# Altium schematic sheet LTE_UART_USB_adapter.SchDoc
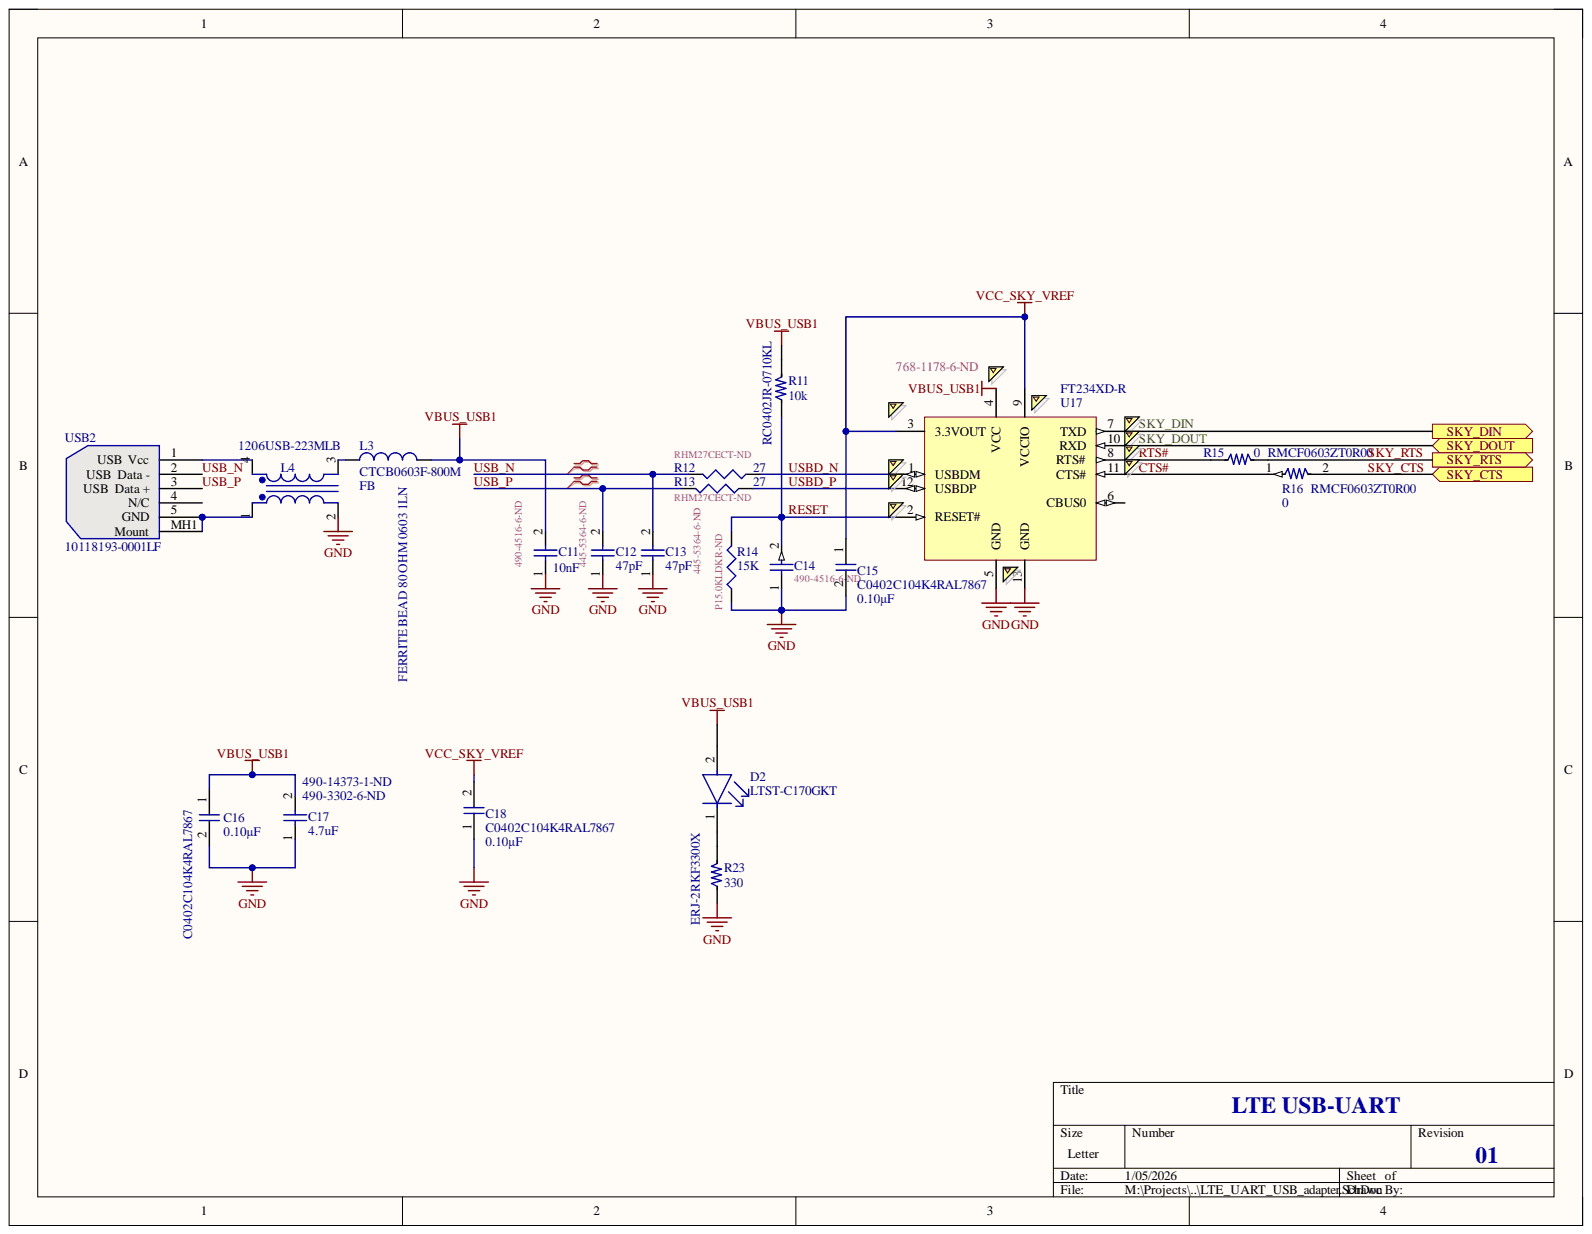
[diagram p0: LTE_UART_USB_adapter.SchDoc]

## Parts
C11  Capacitance: 10nF  Supplier Part Number 1: 490-4516-6-ND  [0402 (1005 Metric)]  (hidden comment: GRM155R71H103KA88D)
C12  Capacitance: 47pF  Supplier Part Number 1: 445-5364-6-ND  [0402 (1005 Metric)]  (hidden comment: C1005C0G1H470G050BA)
C13  Capacitance: 47pF  [0402 (1005 Metric)]  (hidden comment: C1005C0G1H470G050BA)
C14  Supplier Part Number 1: 490-4516-6-ND  [0402 (1005 Metric)]  (hidden comment: GRM155R71H103KA88D)
C15  C0402C104K4RAL7867  Capacitance: 0.10µF  [SMD CAP 1005]
C16  C0402C104K4RAL7867  Capacitance: 0.10µF  [SMD CAP 1005]
C17  Capacitance: 4.7uF  Digi-Key Part Number: 490-14373-1-ND  Supplier Part Number 1: 490-3302-6-ND  [0603 (1608 Metric)]  (hidden comment: GRM188R61A475KAAJD)
C18  C0402C104K4RAL7867  Capacitance: 0.10µF  [SMD CAP 1005]
D2  LTST-C170GKT  [FP-LTST-C170GKT-MFG]
L3  CTCB0603F-800M  value FB  [INDC1608M]
L4  1206USB-223MLB  [1206USB-223MLB]
R11  RC0402JR-0710KL  Resistance_Ohms: 10k  [SMD RES 1005]
R12  value 27  Digi-Key Part Number: RHM27CECT-ND  [SMD RES 1005]  (hidden comment: MCR01MRTJ270)
R13  value 27  Digi-Key Part Number: RHM27CECT-ND  [SMD RES 1005]  (hidden comment: MCR01MRTJ270)
R14  value 15K  Supplier Part Number 1: P15.0KLDKR-ND  [SMD RES 1005]  (hidden comment: ERJ-2RKF1502X)
R15  RMCF0603ZT0R00  [RESC1608M]
R16  RMCF0603ZT0R00  [RESC1608M]
R23  ERJ-2RKF3300X  [SMD RES 1005]
U17  FT234XD-R  Supplier Part Number 1: 768-1178-6-ND  [DFN-12_V]
USB2  10118193-0001LF  [10118193-0001LF Rev01]

## Ports
SKY_CTS, SKY_DIN, SKY_DOUT, SKY_RTS

## Nets
GND: C11.1 C12.1 C13.1 C14.1 C15.2 C16.2 C17.1 C18.1 L4.2 R14.1 R23.1 U17.5(GND) U17.13(GND)
VBUS_USB1: C11.2 C16.1 C17.2 D2.2 L3.1 R11.2 U17.4(VCC)
VCC_SKY_VREF: C15.1 C18.2 U17.3(3.3VOUT) U17.9(VCCIO)
CTS#: R16.1 U17.11(CTS#)
RESET: C14.2 R11.1 R14.2 U17.2(RESET#)
RTS#: R15.1 U17.8(RTS#)
SKY_CTS: R16.2 ; port SKY_CTS
SKY_DIN: U17.7(TXD) ; port SKY_DIN
SKY_DOUT: U17.10(RXD) ; port SKY_DOUT
SKY_RTS: R15.2 ; port SKY_RTS
USB_N: C13.2 R12.2 USB2.2(Data_-)
USB_P: C12.2 R13.2 USB2.3(Data_+)
USBD_N: R12.1 U17.1(USBDM)
USBD_P: R13.1 U17.12(USBDP)
NetD2_1: D2.1 R23.2
NetL3_2: L3.2 L4.3
NetL4_1: L4.1 USB2.5(GND) USB2.MH1(Mount)
NetL4_4: L4.4 USB2.1(Vcc)
NetU17_6: U17.6(CBUS0)
NetUSB2_4: USB2.4(N/C)

## Library data of the parts
C11 = Cap Semi: 10000 pF ±10% 50V Ceramic Capacitor X7R 0402 (1005 Metric); Manufacturer: Murata Electronics North America; Component Kind: Standard; Cost: 0.02558; Digi-Key Part Number: 490-4516-1-ND; Manufacturer Product Number: GRM155R71H103KA88D; PackageDescription: Chip Capacitor; PackageReference: 0402; Signal Integrity: Cap Semi; Simulation: CAP; Supplier 1: Digi-Key; Library Name: TempProbe.SchLib
C12 C13 = Cap Semi: 47 pF ±2% 50V Ceramic Capacitor C0G, NP0 0402 (1005 Metric); Manufacturer: TDK Corporation; Component Kind: Standard; Cost: 0.02558; Digi-Key Part Number: 445-5364-1-ND; Manufacturer Product Number: C1005C0G1H470G050BA; PackageDescription: Chip Capacitor; PackageReference: 0402; Signal Integrity: Cap Semi; Simulation: CAP; Supplier 1: Digi-Key; Library Name: TempProbe.SchLib
  C13: Supplier Part Number 1: 445-5364-6-ND
C14 = Cap Semi: 10000 pF ±10% 50V Ceramic Capacitor X7R 0402 (1005 Metric); Manufacturer: Murata Electronics North America; Capacitance: 10nF; Component Kind: Standard; Cost: 0.02558; Digi-Key Part Number: 490-4516-1-ND; Manufacturer Product Number: GRM155R71H103KA88D; PackageDescription: Chip Capacitor; PackageReference: 0402; Signal Integrity: Cap Semi; Simulation: CAP; Supplier 1: Digi-Key; Library Name: TempProbe.SchLib
C15 C16 C18 = 1000742: 0.1 µF ±10% 16V Ceramic Capacitor X7R 0402 (1005 Metric); Manufacturer: KEMET; Manufacturer_Part_Number1: C0402C104K4RALTU; Item_Phase: Production; Mounting_Type: Surface Mount; Operating_Temperature: -55°C ~ 125°C; Thickness_Max: 0.022" (0.55mm); Value: 0.1uF; Applications: General Purpose; Bom_View: https://app.bom.com/a/YGZ57I1I1KJQDBOE?v=bom.indented; Capacitor_Type: Ceramic; Component Kind: Standard; Costing_View: https://app.bom.com/a/YGZ57I1I1KJQDBOE?v=costing.summary; Database Table Name: Capacitor; Datasheet_Link: http://www.samsungsem.com/kr/support/product-search/mlcc/CL05B104KO5NNNC.jsp; Digi-Key Part Number: 399-C0402C104K4RAL7867CT-ND; Distributor1: Digi-Key; Distributor2: Digi-Key; Distributor_Part_Number1: 399-6342-1-ND; Distributor_Part_Number2: 1276-1001-1-ND; Files_View: https://app.bom.com/a/YGZ57I1I1KJQDBOE?v=files.associations; Library Name: AltiumProductionDatabase.DbLib; Manufacturer1: Kemet; Manufacturer2: Samsung Electro-Mechanics America, Inc; Manufacturer Product Number: C0402C104K4RAL7867; Manufacturer_Part_Number2: CL05B104KO5NNNC; Package_Case: 0402 (1005 Metric); Part_Number: 1000742; Part_Type: Capacitor; Revision: A; Size_Dimension: 0.039" L x 0.020" W (1.00mm x 0.50mm); Sourcing_View: https://app.bom.com/a/YGZ57I1I1KJQDBOE?v=sourcing.relationships; Specs_View: https://app.bom.com/a/YGZ57I1I1KJQDBOE?v=spec.summary; Temperature_Coefficient: X7R; Tolerance: ±10%; Voltage_Rating: 16V
C17 = Cap Semi: 4.7 µF ±10% 10V Ceramic Capacitor X5R 0603 (1608 Metric); Manufacturer: Murata Electronics North America; Component Kind: Standard; Cost: 0.02558; Manufacturer Product Number: GRM188R61A475KAAJD; PackageDescription: Chip Capacitor; PackageReference: 0402; Signal Integrity: Cap Semi; Simulation: CAP; Supplier 1: DigiKey; Supplier 2: Digi-Key; Supplier Part Number 2: 490-14373-1-ND; Library Name: TempProbe.SchLib
D2 = CMP-1674-00002-6: Green 569nm LED Indication - Discrete 2.1V 0805 (2012 Metric); Manufacturer: Lite-On Inc.; Forward Current: 30mA; Lens Color: Colorless; Lens Style: Diffused; Lens Transparency: Clear; Luminous Intensity: 6mcd; Number of Elements: 1; Power Dissipation: 100mW; Reverse Voltage: 5V; Test Current: 10mA; Viewing Angle: 130°; Wavelength: 565nm; Case Code (Imperial): 0805; Case Code (Metric): 2012; Case/Package: 0805; Color: Green; Component Kind: Standard; Depth: 1.25mm; Digi-Key Part Number: 160-1179-1-ND; Dominant Wavelength: 569nm; Forward Voltage: 2.1V; Height: 1.1mm; Illumination Color: Green; Lead Free: Lead Free; Length: 2mm; Library Name: Altium Content Vault; Manufacturer Product Number: LTST-C170GKT; Max Operating Temperature: 85°C; Min Operating Temperature: -55°C; Mount: Surface Mount; Number of LEDs: 1; Number of Pins: 2; Package Quantity: 1; Packaging: Tape and Reel; Power Rating: 100mW; Radiation Hardening: No; Value: LED; Voltage: 5V; Width: 1.25mm
L3 = Inductor: 80 Ohms @ 100 MHz 1 Ferrite Bead 0603 (1608 Metric) 600mA 100mOhm; Manufacturer: Central Technologies; Code_IEC: 1005; Desc: FERRITE BEAD 80 OHM 0603 1LN; Code_JEITA: 0402; Component Kind: Standard; ComponentLink1Description: CIM10U800NC Datasheet; ComponentLink2Description: Datasheet; Cost: 0.02594; Digi-Key Part Number: 4161-CTCB0603F-800M-ND; LatestRevisionDate: 29-May-2009; LatestRevisionNote: IPC-7351 Footprint Added.; Library Name: Miscellaneous Devices.IntLib; Manufacturer Product Number: CTCB0603F-800M; PackageDescription: Chip Inductor; PackageReference: 0402-A; Published: 8-Jun-2000; Signal Integrity: Inductor; Simulation: INDUCTOR; ComponentLink1URL: C:\Users\Roman.Chak\Documents\Projects\UVDI-360\Docs\Datasheets\Ferrite Bid\CIB_CIM10_ds.pdf; ComponentLink2URL: C:\Users\Roman.Chak\Documents\Projects\UVDI-360\Docs\Datasheets\Ferrite Bid\ctcbf-pwr.pdf; Publisher: Altium Limited
L4 = Trans Ideal: Common Mode Chokes / Filters; Manufacturer: Coilcraft; Component Kind: Standard; ComponentLink1Description: C Datasheet; LatestRevisionDate: 17-Jul-2002; LatestRevisionNote: Re-released for DXP Platform.; Library Name: Miscellaneous Devices.IntLib; Manufacturer Product Number: 1206USB-223MLB; PackageDescription: Transformer; 4 Leads; PackageReference: TRF_4; Published: 8-Jun-2000; Simulation: IDEALTRANS; ComponentLink1URL: C:\Users\chakr\OneDrive\Documents\Projects\WiFi Node Rev3.0-3.1-4.0\Docs\Datasheets\Firter Bid\DS_FT2232D.pdf; Publisher: Altium Limited
R11 = 1000795: 10 kOhms ±5% 0.063W, 1/16W Chip Resistor 0402 (1005 Metric) Moisture Resistant Thick Film; Manufacturer: YAGEO; Manufacturer1: Bourns Inc; Manufacturer_Part_Number1: CR0402-FX-1002GLF; Item_Phase: Production; Mounting_Type: Surface Mount; Number_of_Terminations: 2; Operating_Temperature: -55°C ~ 155°C; Temperature_Coefficient: ±100ppm/°C; Tolerance: ±1%; Bom_View: https://app.bom.com/a/P7QWY9S9SBAH42BP?v=bom.indented; Component Kind: Standard; Composition: Thick Film; Costing_View: https://app.bom.com/a/P7QWY9S9SBAH42BP?v=costing.summary; Database Table Name: Resistor; Datasheet_Link: http://media.digikey.com/pdf/Data%20Sheets/Samsung%20PDFs/RC_Series_ds.pdf; Digi-Key Part Number: 311-10KJRCT-ND; Distributor1: Digi-Key; Distributor2: Digi-Key; Distributor_Part_Number1: CR0402-FX-1002GLFTR-ND; Distributor_Part_Number2: CR0402-FX-1002GASTR-ND; Features: Moisture Resistant; Files_View: https://app.bom.com/a/P7QWY9S9SBAH42BP?v=files.associations; Height: 0.016" (0.40mm); Library Name: AltiumProductionDatabase.DbLib; Manufacturer2: Bourns Inc; Manufacturer Product Number: RC0402JR-0710KL; Manufacturer_Part_Number2: CR0402-FX-1002GAS; Part_Number: RC0402JR-0710KL; Part_Type: Resistor; Power: 0.063W, 1/16W; Resistor_Package: 0402 (1005 Metric); Revision: A; Size_Dimension: 0.039" L x 0.020" W (1.00mm x 0.50mm); Sourcing_View: https://app.bom.com/a/P7QWY9S9SBAH42BP?v=sourcing.relationships; Specs_View: https://app.bom.com/a/P7QWY9S9SBAH42BP?v=spec.summary
R12 R13 = Res3: RES SMD 27 OHM 5% 1/16W 0402; Manufacturer: Rohm Semiconductor; Component Kind: Standard; Cost: 0.00384; LatestRevisionDate: 29-May-2009; LatestRevisionNote: IPC-7351 Footprint Added.; Library Name: Miscellaneous Devices.IntLib; Manufacturer Part Number: MCR01MRTJ270; PackageDescription: Chip Resistor; PackageReference: J1-0603; Published: 8-Jun-2000; Signal Integrity: Res; Simulation: RESISTOR; Supplier 1: Digi-Key; Supplier Part Number 1: RHM27CECT-ND; Publisher: Altium Limited
R14 = Res3: RES SMD 15K OHM 1% 1/10W 0402; Manufacturer: Panasonic Electronic Components; Component Kind: Standard; Cost: 0.00384; Digi-Key Part Number: P15.0KLCT-ND; LatestRevisionDate: 29-May-2009; LatestRevisionNote: IPC-7351 Footprint Added.; Library Name: Miscellaneous Devices.IntLib; Manufacturer Part Number: ERJ-2RKF1502X; PackageDescription: Chip Resistor; PackageReference: J1-0603; Published: 8-Jun-2000; Signal Integrity: Res; Simulation: RESISTOR; Supplier 1: Digi-Key; Publisher: Altium Limited
R15 R16 = 1001176: 0 Ohms Jumper Chip Resistor 0603 (1608 Metric) Automotive AEC-Q200 Thick Film; Manufacturer: Stackpole Electronics Inc; Item_Phase: Production; Mounting_Type: Surface Mount; Number_of_Terminations: 2; Operating_Temperature: -55°C ~ 125°C; Power: 0.25W, 1/4W; Resistance: 0; Resistor_Package: 0805 (2012 Metric); Temperature_Coefficient: ±100ppm/°C; Tolerance: ±1%; Bom_View: https://app.bom.com/a/Q8RXZATATCBI53HK?v=bom.indented; Component Kind: Standard; Composition: Thick Film; Costing_View: https://app.bom.com/a/Q8RXZATATCBI53HK?v=costing.summary; Database Table Name: Resistor; Datasheet_Link: http://industrial.panasonic.com/lecs/www-data/pdf/AOA0000/AOA0000CE3.pdf; Digi-Key Part Number: RMCF0603ZT0R00CT-ND; Distributor1: Digi-Key; Distributor_Part_Number1: RMCF0603ZT0R00CT-ND; Features: Automotive AEC-Q200, Current Sense; Files_View: https://app.bom.com/a/Q8RXZATATCBI53HK?v=files.associations; Height: 0.028" (0.70mm); Library Name: AltiumProductionDatabase.DbLib; Manufacturer1: Stackpole Electronics Inc; Manufacturer Product Number: RMCF0603ZT0R00; Part_Number: RMCF0603ZT0R00; Part_Type: Resistor; Revision: A; Size_Dimension: 0.079" L x 0.049" W (2.00mm x 1.25mm); Sourcing_View: https://app.bom.com/a/Q8RXZATATCBI53HK?v=sourcing.relationships; Specs_View: https://app.bom.com/a/Q8RXZATATCBI53HK?v=spec.summary
R23 = 1001162: 330 Ohms ±1% 0.1W, 1/10W Chip Resistor 0402 (1005 Metric) Automotive AEC-Q200 Thick Film; Manufacturer: Panasonic Electronic Components; Item_Phase: Production; Mounting_Type: Surface Mount; Number_of_Terminations: 2; Operating_Temperature: -55°C ~ 155°C; Resistance: 330; Temperature_Coefficient: ±100ppm/°C; Bom_View: https://app.bom.com/a/1J28AL4L4NMTGF0H?v=bom.indented; Component Kind: Standard; Composition: Thick Film; Costing_View: https://app.bom.com/a/1J28AL4L4NMTGF0H?v=costing.summary; Database Table Name: Resistor; Datasheet_Link: http://industrial.panasonic.com/www-cgi/jvcr13pz.cgi?E+PZ+3+AOA0002+ERJ2RKF3300X+7+WW; Digi-Key Part Number: P330LCT-ND; Distributor1: Digi-Key; Distributor_Part_Number1: P330LCT-ND; Features: Automotive AEC-Q200; Files_View: https://app.bom.com/a/1J28AL4L4NMTGF0H?v=files.associations; Height: 0.016" (0.40mm); Library Name: AltiumProductionDatabase.DbLib; Manufacturer1: Panasonic Electronic Components; Manufacturer Product Number: ERJ-2RKF3300X; Manufacturer_Part_Number1: ERJ-2RKF3300X; Part_Number: 1001162; Part_Type: Resistor; Power: 0.1W, 1/10W; Resistor_Package: 0402 (1005 Metric); Revision: A; Size_Dimension: 0.039" L x 0.020" W (1.00mm x 0.50mm); Sourcing_View: https://app.bom.com/a/1J28AL4L4NMTGF0H?v=sourcing.relationships; Specs_View: https://app.bom.com/a/1J28AL4L4NMTGF0H?v=spec.summary; Tolerance: ±1%
U17 = CMP-0248-00027-1: IC USB SERIAL BASIC UART 12DFN; Manufacturer: FTDI, Future Technology Devices International Ltd; Component Kind: Standard; ComponentLink1Description: Manufacturer URL; ComponentLink1URL: http://www.ftdichip.com/; ComponentLink2Description: Datasheet Link; ComponentLink2URL: http://www.ftdichip.com/Support/Documents/DataSheets/ICs/DS_FT234XD.pdf; ComponentLink3Description: Datasheet; Cost: 2.17; DatasheetVersion: ver 1.1, Feb-2013; Digi-Key Part Number: 768-1178-1-ND; Library Name: Altium Content Vault; Manufacturer Part Number: FT234XD-R; PackageDescription: 12-Pin Dual Flat No-Lead, 3 x 3 mm Body, 0.45 mm Pitch, Exposed Pad; PackageReference: DFN-12; PackageVersion: Feb-13; PartNumber: FT234XD-R; Supplier 1: Digi-Key; ComponentLink3URL: C:\Users\Roman.Chak\Documents\Projects\UVDI-360\Docs\Datasheets\FTDI\DS_FT234XD.pdf
USB2 = USB mini: USB - micro B USB 2.0 Receptacle Connector 5 Position Surface Mount, Right Angle, Horizontal; Manufacturer: FCI; Alternative: None; Assembly Note: Install; Loc: Vendor; Protorype Price: 0.46000; Value: CONN USB MICRO B RECPT SMT R/A; Component Kind: Standard; ComponentLink1Description: C datasheet; ComponentLink2Description: Folder; Cost: 0.25988; Digi-Key Part Number: 609-4616-1-ND; Library Name: USB.SchLib; Manufacturer Part Number: 10118193-0001LF; Price for 100: 0.30320; Purchased by: Vendor; Supplier 1: Digi-Key; Supplier 2: Digi-Key; Type: SMD; ComponentLink1URL: C:\Users\rchak\Documents\Projects\WiFi Node Rev2.0\Docs\Datasheets\USB\10118193.pdf; ComponentLink2URL: C:\Users\rchak\Documents\Projects\WiFi Node Rev2.0\Docs\Datasheets\USB
